# Altium schematic sheet PIC32CMGV.SchDoc(PIC32CMGV)
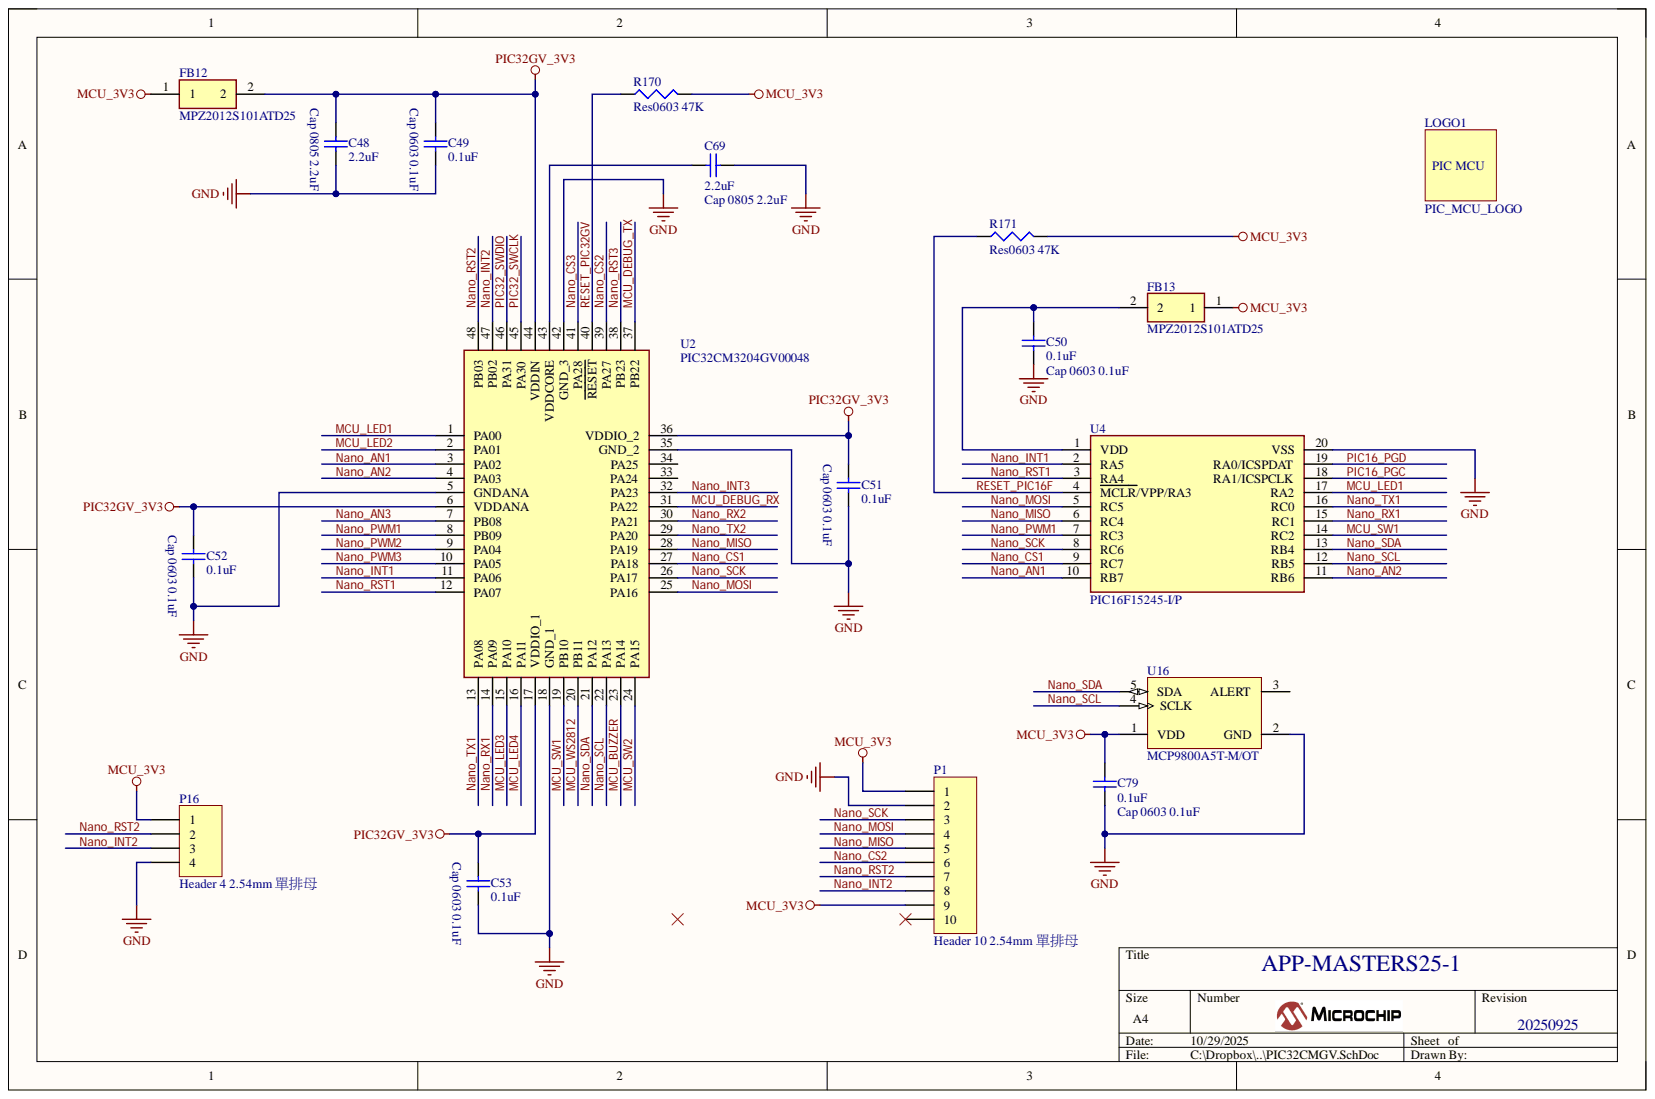
[diagram p0: PIC32CMGV.SchDoc]

## Parts
C48  Cap 0805 2.2uF  value 2.2uF  [C0805]
C49  Cap 0603 0.1uF  value 0.1uF  [0603C]
C50  Cap 0603 0.1uF  value 0.1uF  [0603C]
C51  Cap 0603 0.1uF  value 0.1uF  [0603C]
C52  Cap 0603 0.1uF  value 0.1uF  [0603C]
C53  Cap 0603 0.1uF  value 0.1uF  [0603C]
C69  Cap 0805 2.2uF  value 2.2uF  [C0805]
C79  Cap 0603 0.1uF  value 0.1uF  [0603C]
FB12  MPZ2012S101ATD25  [BEADC2012X105N]
FB13  MPZ2012S101ATD25  [BEADC2012X105N]
LOGO1  PIC_MCU_LOGO  [PIC_MCU_LOGO]
P1  Header 10 2.54mm 單排母  [HDR1X10]
P16  Header 4 2.54mm 單排母  [HDR1X4]
R170  Res0603 47K  value 47K  [0603R]
R171  Res0603 47K  value 47K  [0603R]
U2  PIC32CM3204GV00048  [QFP50P900X900X120-48N]  (value not drawn)
U4  PIC16F15245-I/P  [DIP794W56P254L2591H533Q20N]
U16  MCP9800A5T-M/OT  [MCP1754_SOT-23-CT5_M]

## Nets
GND: C48.1 C49.1 C50.1 C51.1 C52.1 C53.1 C69.2 C79.1 P1.2 P16.4 U2.5(GNDANA) U2.18(GND_1) U2.35(GND_2) U2.42(GND_3) U4.20(VSS) U16.2(GND)
MCU_3V3: C79.2 FB12.1 FB13.1 P1.1 P1.9 P16.1 R170.2 R171.2 U16.1(VDD)
PIC32GV_3V3: C48.2 C49.2 C51.2 C52.2 C53.2 FB12.2 U2.6(VDDANA) U2.17(VDDIO_1) U2.36(VDDIO_2) U2.44(VDDIN)
MCU_BUZZER: U2.23(PA14)
MCU_DEBUG_RX: U2.31(PA22)
MCU_DEBUG_TX: U2.37(PB22)
MCU_LED1: U2.1(PA00) U4.17(RA2)
MCU_LED2: U2.2(PA01)
MCU_LED3: U2.15(PA10)
MCU_LED4: U2.16(PA11)
MCU_SW1: U2.19(PB10) U4.14(RC2)
MCU_SW2: U2.24(PA15)
MCU_WS2812: U2.20(PB11)
Nano_AN1: U2.3(PA02) U4.10(RB7)
Nano_AN2: U2.4(PA03) U4.11(RB6)
Nano_AN3: U2.7(PB08)
Nano_CS1: U2.27(PA18) U4.9(RC7)
Nano_CS2: P1.6 U2.39(PA27)
Nano_CS3: U2.41(PA28)
Nano_INT1: U2.11(PA06) U4.2(RA5)
Nano_INT2: P1.8 P16.3 U2.47(PB02)
Nano_INT3: U2.32(PA23)
Nano_MISO: P1.5 U2.28(PA19) U4.6(RC4)
Nano_MOSI: P1.4 U2.25(PA16) U4.5(RC5)
Nano_PWM1: U2.8(PB09) U4.7(RC3)
Nano_PWM2: U2.9(PA04)
Nano_PWM3: U2.10(PA05)
Nano_RST1: U2.12(PA07) U4.3(RA4)
Nano_RST2: P1.7 P16.2 U2.48(PB03)
Nano_RST3: U2.38(PB23)
Nano_RX1: U2.14(PA09) U4.15(RC1)
Nano_RX2: U2.30(PA21)
Nano_SCK: P1.3 U2.26(PA17) U4.8(RC6)
Nano_SCL: U2.22(PA13) U4.12(RB5) U16.4(SCLK)
Nano_SDA: U2.21(PA12) U4.13(RB4) U16.5(SDA)
Nano_TX1: U2.13(PA08) U4.16(RC0)
Nano_TX2: U2.29(PA20)
PIC16_PGC: U4.18(RA1/ICSPCLK)
PIC16_PGD: U4.19(RA0/ICSPDAT)
PIC32_SWCLK: U2.45(PA30)
PIC32_SWDIO: U2.46(PA31)
RESET_PIC16F: R171.1 U4.4(MCLR/VPP/RA3)
RESET_PIC32GV: R170.1 U2.40(RESET)
NetC50_2: C50.2 FB13.2 U4.1(VDD)
NetC69_1: C69.1 U2.43(VDDCORE)

Not connected: P1 10; U2 33(PA24) 34(PA25); U16 3(ALERT)

## Library data of the parts
C48 C69 = Cap 0805: Capacitor (Semiconductor SIM Model); Component Kind: Standard; LatestRevisionDate: 29-May-2009; LatestRevisionNote: IPC-7351 Footprint Added.; Library Name: MCHP_Parts_2016.SchLib; PackageDescription: Chip Capacitor; PackageReference: C1206; Published: 8-Jun-2000; Publisher: Altium Limited
C49-C53 C79 = Cap 0603: Capacitor (Semiconductor SIM Model); Component Kind: Standard; LatestRevisionDate: 29-May-2009; LatestRevisionNote: IPC-7351 Footprint Added.; Library Name: MCHP_Parts_2016.SchLib; PackageDescription: Chip Capacitor; PackageReference: C0603; Published: 8-Jun-2000; Publisher: Altium Limited
FB12 FB13 = MPZ2012S221AT000: Ferrite Bead; Manufacturer_Name: TDK; Arrow Part Number: MPZ2012S221AT000; Arrow Price/Stock: https://www.arrow.com/en/products/mpz2012s221at000/tdk?region=nac; Component Kind: Standard; Datasheet Link: https://product.tdk.com/system/files/dam/doc/product/emc/emc/beads/catalog/beads_commercial_power_mpz2012_en.pdf; Height: 1.05mm; Library Name: SamacSys.SchLib; Manufacturer_Part_Number: MPZ2012S221AT000; Mouser Part Number: 810-MPZ2012S221AT000; Mouser Price/Stock: https://www.mouser.co.uk/ProductDetail/TDK/MPZ2012S221AT000?qs=pLY5GE0xrmJYuLs3YjLRbg%3D%3D
P1 = Header 10: Header, 10-Pin; Component Kind: Standard; LatestRevisionDate: 17-Jul-2002; LatestRevisionNote: Re-released for DXP Platform.; Library Name: MCHP_Parts_2016.SchLib; Publisher: Altium Limited
P16 = Header 4: Header, 4-Pin; Component Kind: Standard; LatestRevisionDate: 17-Jul-2002; LatestRevisionNote: Re-released for DXP Platform.; Library Name: MCHP_Parts_2016.SchLib; Publisher: Altium Limited
R170 R171 = Res0603: Resistor; Component Kind: Standard; LatestRevisionDate: 29-May-2009; LatestRevisionNote: IPC-7351 Footprint Added.; Library Name: MCHP_Parts_2016.SchLib; PackageDescription: Chip Resistor; PackageReference: J1-0603; Published: 8-Jun-2000; Publisher: Altium Limited
U2 = ATSAMD21G17A-AUT: Integrated Circuit; Manufacturer_Name: Microchip; Arrow Part Number: ATSAMD21G17A-AUT; Arrow Price/Stock: https://www.arrow.com/en/products/atsamd21g17a-aut/microchip-technology?utm_currency=USD&region=nac; Component Kind: Standard; Datasheet Link: http://ww1.microchip.com/downloads/en/devicedoc/40001884a.pdf; Height: 1.2mm; Manufacturer_Part_Number: ATSAMD21G17A-AUT; Mouser Part Number: 556-ATSAMD21G17A-AUT; Mouser Price/Stock: https://www.mouser.co.uk/ProductDetail/Microchip-Technology/ATSAMD21G17A-AUT?qs=KLFHFgXTQiBbK5wcS811Rw%3D%3D
U4 = PIC16F15245-I_P: Integrated Circuit; Manufacturer_Name: Microchip; Component Kind: Standard; Datasheet Link: https://ww1.microchip.com/downloads/en/DeviceDoc/PIC16F1522545_Full_Featured_1420-Pin_Microcontrollers_Data_Sheet_20006389B.pdf; Height: 5.334mm; Manufacturer_Part_Number: PIC16F15245-I/P; Mouser Part Number: 579-PIC16F15245-I/P; Mouser Price/Stock: https://www.mouser.co.uk/ProductDetail/Microchip-Technology/PIC16F15245-I-P?qs=W%2FMpXkg%252BdQ6A4FqMvOiNKA%3D%3D
U16 = MCP9800A5T-M/OT: 2-Wire High-Accuracy Temperature Sensor, Slave Address 101, 5-Pin SOT-23, -55蚓 to +125蚓 Temperature, Tape and Reel; Code_IPC: SOT23-5; Component Kind: Standard; ComponentLink1Description: Datasheet; ComponentLink1URL: http://ww1.microchip.com/downloads/en/DeviceDoc/21909d.pdf; ComponentLink2Description: Packaging Specification; ComponentLink2URL: http://www.microchip.com/stellent/groups/techpub_sg/documents/packagingspec/en012702.pdf; ComponentLink3Description: Microchip.com Home; ComponentLink3URL: http://www.microchip.com/; DatasheetVersion: revD, Nov-2010; LatestRevisionDate: 04-Jan-2011; LatestRevisionNote: Sch pins 4 & 5 swapped.; Library Name: MCHP_Parts_2016.SchLib; PackageDescription: 5-Lead Plastic Small Outline Transistor (OT) [SOT-23]; PackageReference: SOT-23-OT5; PackageVersion: revBB, Aug-2009; Published: 29-Jan-2009; Supplier 1: Digi-Key; Supplier 2: Digi-Key; Supplier 3: Digi-Key; Supplier Part Number 1: MCP9800A5T-M/OTTR-ND; Supplier Part Number 2: MCP9800A5T-M/OTCT-ND; Supplier Part Number 3: MCP9800A5T-M/OTDKR-ND; Publisher: Altium Limited
LOGO1 = PIC_MCU_LOGO: Component Kind: Standard; Library Name: MCHP_Parts_2016.SchLib
